# Altium schematic sheet 03_ALTERNATIVE_LCD_DRIVER.SchDoc(03_ALTERNATIVE_LCD_DRIVER)
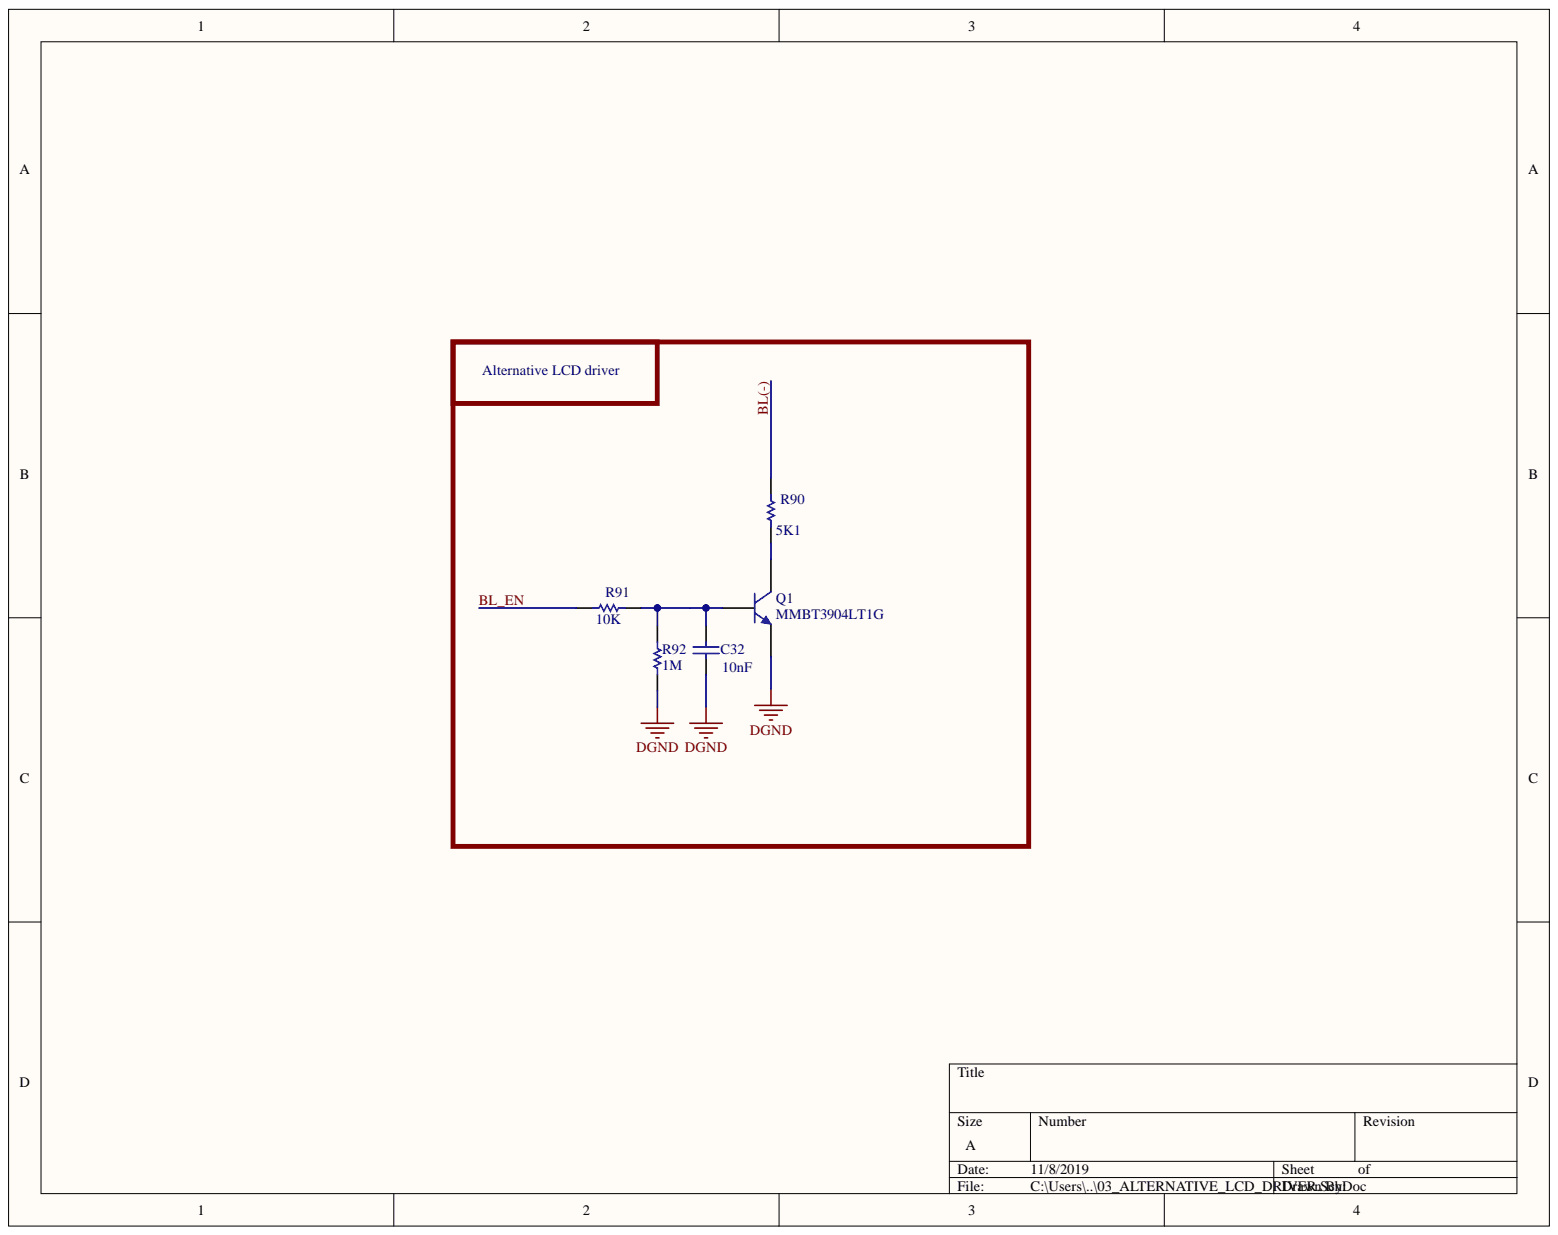
[diagram p0: 03_ALTERNATIVE_LCD_DRIVER.SchDoc]

## Parts
C32  Capacitance: 10nF  [RES_0603_V1]  (hidden comment: CAP_SMD)
Q1  MMBT3904LT1G  [SOT23(TO-236)]
R90  004_Value: 5K1  [RES_1206_V1]  (hidden comment: RES_SMD)
R91  004_Value: 10K  [RES_0603_V1]  (hidden comment: RES_SMD)
R92  value 1M  [RES_0603_V1]  (hidden comment: RES_SMD)

## Nets
DGND: C32.1 Q1.2 R92.1
BL(-): R90.2
BL_EN: R91.1
NetC32_2: C32.2 Q1.1 R91.2 R92.2
NetQ1_3: Q1.3 R90.1

## Library data of the parts
C32 = CAP_SMD: 017_Manufacturer-1 PN 1: GRM188R71H103KA01D; 016_Manufacturer-1 1: Murata; Voltage Rating (DC): 50V; Tolerance: 10%; Material: Ceramic; Insulation Resistance: 10GR; Dielectric: X7R; Width: 0.031inch; Voltage Rating: 50V; Voltage: 50V; Thickness: 0.035inch; Termination: SMD/SMT; Supplier Part Number 1: GRM188R71H103KA01D; Supplier 1: Avnet; Stock 1: 328000; RoHS: Yes; REACH SVHC: Unknown; Packaging: Tape and Reel; Package Quantity: 1; Number of Pins: 2; Mount: Surface Mount; Min Operating Temperature: -55°C; Max Operating Temperature: 125°C; Library Name: ElectricMeter.SchLib; Length: 1.6mm; Lead Free: Lead Free; Height: 0.8mm; Dielectric Material: Ceramic; Depth: 0.8mm; ComponentLink11URL: https://datasheet.ciiva.com/48428/nmgrm-data-en-48428591.pdf?src-supplier=Distrelec; ComponentLink11Description: https://datasheet.ciiva.com/48428/nmgrm-data-en-48428591.pdf?src-supplier=Distrelec; ComponentLink10URL: https://datasheet.ciiva.com/129/partnumbering-e-01-129871.pdf?src-supplier=Digi-Key; ComponentLink10Description: https://datasheet.ciiva.com/129/partnumbering-e-01-129871.pdf?src-supplier=Digi-Key; ComponentLink9URL: https://datasheet.ciiva.com/48428/nmgrm-data-en-48428590.pdf?src-supplier=Distrelec; ComponentLink9Description: https://datasheet.ciiva.com/48428/nmgrm-data-en-48428590.pdf?src-supplier=Distrelec; ComponentLink8URL: https://datasheet.ciiva.com/129/partnumbering-e-01-129870.pdf?src-supplier=Digi-Key; ComponentLink8Description: https://datasheet.ciiva.com/129/partnumbering-e-01-129870.pdf?src-supplier=Digi-Key; ComponentLink7URL: https://datasheet.ciiva.com/28534/1769893-28534235.pdf?src-supplier=Element14; ComponentLink7Description: https://datasheet.ciiva.com/28534/1769893-28534235.pdf?src-supplier=Element14; ComponentLink6URL: https://datasheet.ciiva.com/7937/1747461-7937656.pdf?src-supplier=Element14; ComponentLink6Description: https://datasheet.ciiva.com/7937/1747461-7937656.pdf?src-supplier=Element14; ComponentLink5URL: https://datasheet.ciiva.com/7937/1747461-7937655.pdf?src-supplier=Element14; ComponentLink5Description: https://datasheet.ciiva.com/7937/1747461-7937655.pdf?src-supplier=Element14; ComponentLink4URL: https://datasheet.ciiva.com/7937/4360-7937654.pdf?src-supplier=Element14; ComponentLink4Description: https://datasheet.ciiva.com/7937/4360-7937654.pdf?src-supplier=Element14; ComponentLink3URL: https://datasheet.ciiva.com/29202/451715-da-01-en-vs-kondensator-0603-29202047.pdf?src-supplier=Conrad; ComponentLink3Description: https://datasheet.ciiva.com/29202/451715-da-01-en-vs-kondensator-0603-29202047.pdf?src-supplier=Conrad; ComponentLink2URL: https://datasheet.ciiva.com/11786/0900766b8003061f-11786516.pdf?src-supplier=RSComponents; ComponentLink2Description: https://datasheet.ciiva.com/11786/0900766b8003061f-11786516.pdf?src-supplier=RSComponents; ComponentLink1URL: https://datasheet.ciiva.com/129/product-129872.pdf?src-supplier=Digi-Key; ComponentLink1Description: https://datasheet.ciiva.com/129/product-129872.pdf?src-supplier=Digi-Key; Component Kind: Standard; Case Code (Metric): 1608; Case Code (Imperial): 0603; Case/Package: 0603
Q1 = MMBT3904LT1G: TRANS NPN 40V 0.2A SOT23; 016_Manufacturer-1: ON Semiconductor; Type: General Purpose; Transition Frequency: 300MHz; Power Dissipation: 300mW; Parameter Name: Tape and Reel; Number of Elements: 1; Max Collector Current: 200mA; hFE Min: 100; Emitter Base Voltage (VEBO): 6V; Element Configuration: Single; Collector Emitter Saturation Voltage: 300mV; Collector Base Voltage (VCBO): 60V; Width: 1.3mm; Voltage Rating (DC): 40V; Supplier Part Number 1: MMBT3904LT1G; Supplier 1: Verical; Stock 1: 0; REACH SVHC: No SVHC; Radiation Hardening: No; Polarity: NPN; Package Quantity: 1; Number of Pins: 3; Min Operating Temperature: -55°C; Max Power Dissipation: 300mW; Max Operating Temperature: 150°C; Max Frequency: 300MHz; Max Breakdown Voltage: 40V; Library Name: ElectricMeter.SchLib; Length: 2.9mm; Lead Free: Lead Free; Height: 0.94mm; Gain Bandwidth Product: 300MHz; Frequency: 300MHz; Current Rating: 200mA; Contact Plating: Matte,Tin; ComponentLink8URL: http://www.farnell.com/datasheets/1708269.pdf?src-supplier=Element14; ComponentLink8Description: http://www.farnell.com/datasheets/1708269.pdf?src-supplier=Element14; ComponentLink7URL: https://www.alliedelec.com/m/d/1a5811649abd01351be5b86b87f7b036.pdf?src-supplier=Allied+Electronics; ComponentLink7Description: https://www.alliedelec.com/m/d/1a5811649abd01351be5b86b87f7b036.pdf?src-supplier=Allied+Electronics; ComponentLink6URL: https://www.verical.com/server-webapp/html/getDatasheet?partID=53263&src-supplier=Verical; ComponentLink6Description: https://www.verical.com/server-webapp/html/getDatasheet?partID=53263&src-supplier=Verical; ComponentLink5URL: http://www.onsemi.com/pub_link/Collateral/MMBT3904LT1-D.PDF?src-supplier=Avnet; ComponentLink5Description: http://www.onsemi.com/pub_link/Collateral/MMBT3904LT1-D.PDF?src-supplier=Avnet; ComponentLink4URL: http://www.onsemi.com/pub_link/Collateral/MMBT3904LT1-D.PDF?src-supplier=Avnet+Asia; ComponentLink4Description: http://www.onsemi.com/pub_link/Collateral/MMBT3904LT1-D.PDF?src-supplier=Avnet+Asia; ComponentLink3URL: http://www.mouser.com/ds/2/308/MMBT3904LT1-D-113169.pdf?src-supplier=Mouser; ComponentLink3Description: http://www.mouser.com/ds/2/308/MMBT3904LT1-D-113169.pdf?src-supplier=Mouser; ComponentLink2URL: http://www.onsemi.com/pub/Collateral/MMBT3904LT1-D.PDF?src-supplier=Digi-Key; ComponentLink2Description: http://www.onsemi.com/pub/Collateral/MMBT3904LT1-D.PDF?src-supplier=Digi-Key; ComponentLink1URL: http://www.onsemi.com/pub/Collateral/MMBT3904LT1-D.PDF?src-supplier=Future+Electronics; ComponentLink1Description: http://www.onsemi.com/pub/Collateral/MMBT3904LT1-D.PDF?src-supplier=Future+Electronics; Component Kind: Standard; Collector Emitter Voltage (VCEO): 40V; Collector Emitter Breakdown Voltage: 40V; Case/Package: SOT-23-3; 017_Manufacturer-1 PN: MMBT3904LT1G
R90 = RES_SMD: 017_Manufacture-1 PN: RC1206FR-075K1L; 016_Manufacturer-1: Yageo; Library Name: ElectricMeter.SchLib; Component Kind: Standard
R91 = RES_SMD: RES.(1608) 0603 10K Ohms 5% 1/10W-S 100PPM; 017_Manufacturer-1 PN: 0603SAJ0103T5E; 016_Manufacturer-1: Royalohm; Library Name: ElectricMeter.SchLib; Component Kind: Standard
R92 = RES_SMD: Chip Resistor, 560K, %1, 0603; Manufacturer PN: RC0603FR-071ML; Manufacturer: Yageo; SMT/THT: SMT; Model 4: BM32; Model 3: BM31; Model 2: BM22; Model 1: BM21; Status: MP; Manufacturer PN 2: -; Manufacturer 2: -; Library Name: BM_COMM_180705.IntLib; Designation: Resistor; Component Kind: Standard
